# Altium schematic sheet Connectors.SchDoc(Connectors)
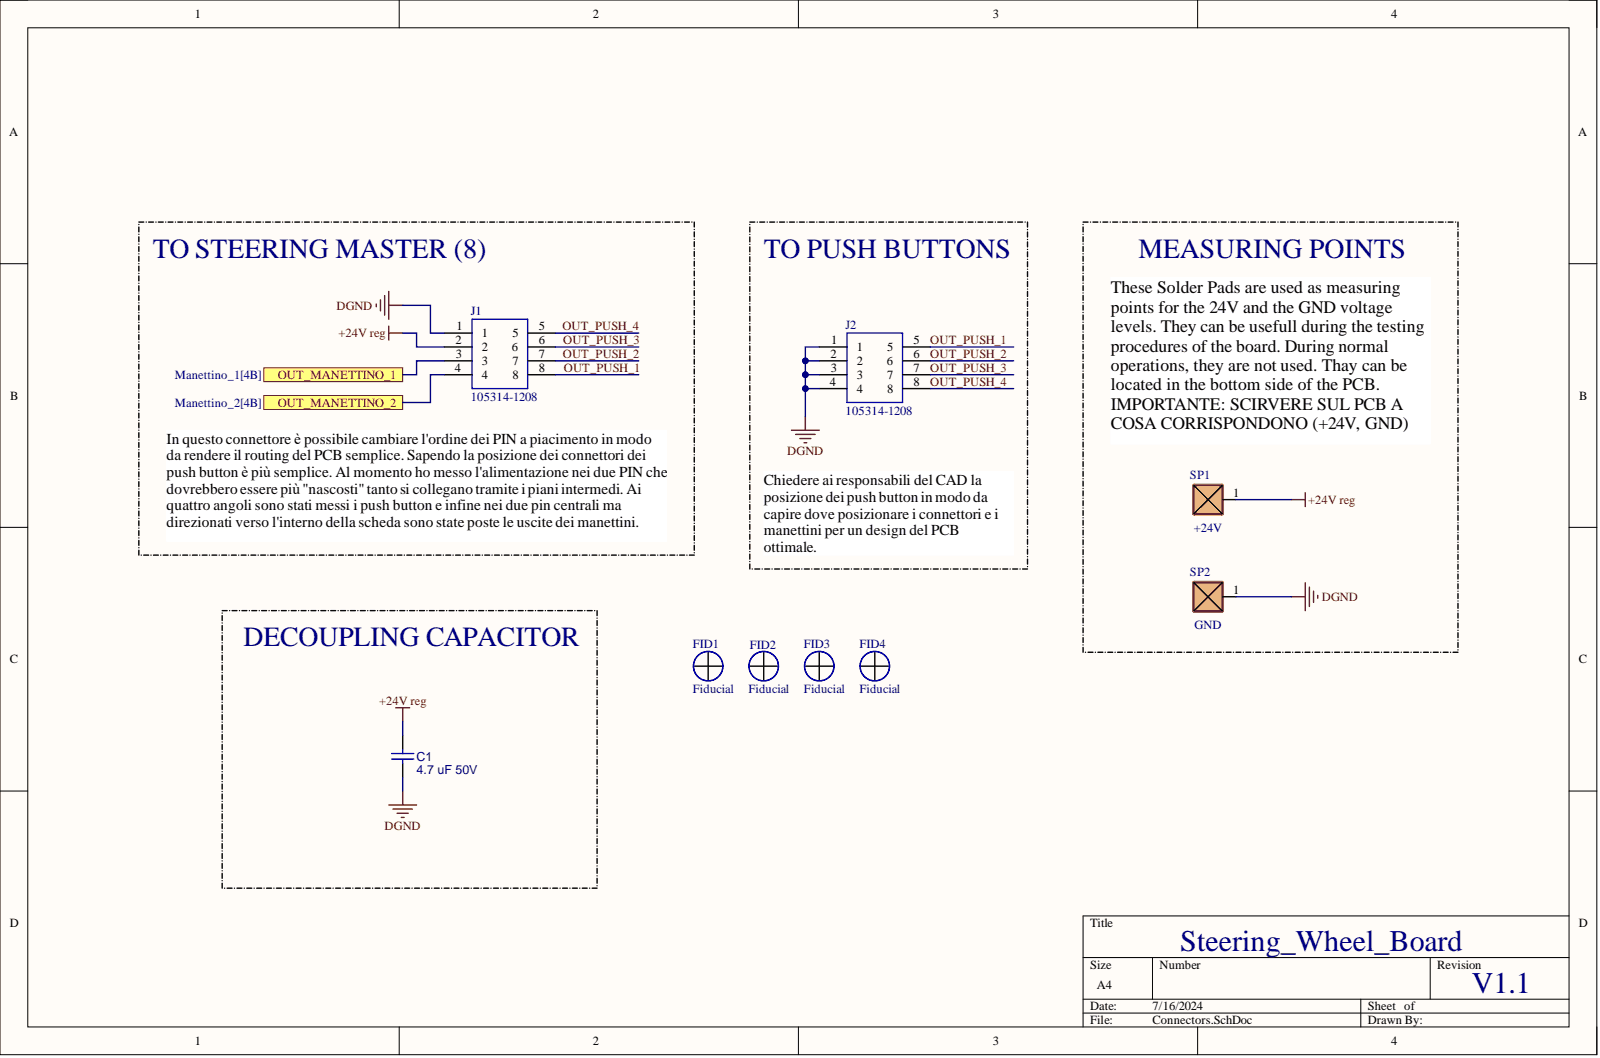
[diagram p0: Connectors.SchDoc]

## Parts
C1  4.7 uF 50V
FID1  Fiducial
FID2  Fiducial
FID3  Fiducial
FID4  Fiducial
J1  105314-1208
J2  105314-1208
SP1  +24V
SP2  GND

## Ports
OUT_MANETTINO_1, OUT_MANETTINO_2

## Nets
+24V reg: C1.2 J1.2 SP1.1
DGND: C1.1 J1.1 J2.1 J2.2 J2.3 J2.4 SP2.1
OUT_MANETTINO_1: J1.3 ; port OUT_MANETTINO_1
OUT_MANETTINO_2: J1.4 ; port OUT_MANETTINO_2
OUT_PUSH_1: J1.8 J2.5
OUT_PUSH_2: J1.7 J2.6
OUT_PUSH_3: J1.6 J2.7
OUT_PUSH_4: J1.5 J2.8

## Library data of the parts
C1 = CMP-001-00085-2: GRM21BR61H475ME51L; Tech: SMD; Component Kind: Standard; ComponentLink1Description: Website; ComponentLink1URL: https://www.mouser.it/ProductDetail/Murata-Electronics/GRM21BR61H475ME51L?qs=eeBpzGFlv%252B%2Fg0wAeHy0eJw%3D%3D; Package: 0805; Library Name: EMEA- Squadra Corse PoliTO-  Politecnico di Torino
FID1-FID4 = CMP-00028-00007-10: Component Kind: Standard; Library Name: EMEA- Squadra Corse PoliTO-  Politecnico di Torino
J1 J2 = CMP-002-000010-1: Molex Electronics, Series Number 105314, 2.5mm Pitch 8 Way 2 Row Right Angle PCB Header, Solder Termination, 6.5A; Component Kind: Standard; Datasheet Link: http://www.molex.com/webdocs/datasheets/pdf/en-us//1053141208_PCB_HEADERS.pdf; Design Item ID: 105314-1208; Manufacturer_Name: Molex; Manufacturer_Part_Number: 105314-1208; Mouser Part Number: 538-105314-1208; Mouser Price/Stock: https://www.mouser.co.uk/ProductDetail/Molex/105314-1208?qs=PqFvFmEiOr4OLOIhmWCWEA%3D%3D; Source: 105314-1208.SchLib; Library Name: EMEA- Squadra Corse PoliTO-  Politecnico di Torino
SP1 SP2 = CMP-00000-21: Solder Pad 5mm; Component Kind: Standard; Library Name: EMEA- Squadra Corse PoliTO-  Politecnico di Torino
